# Altium schematic sheet 接收器.SchDoc
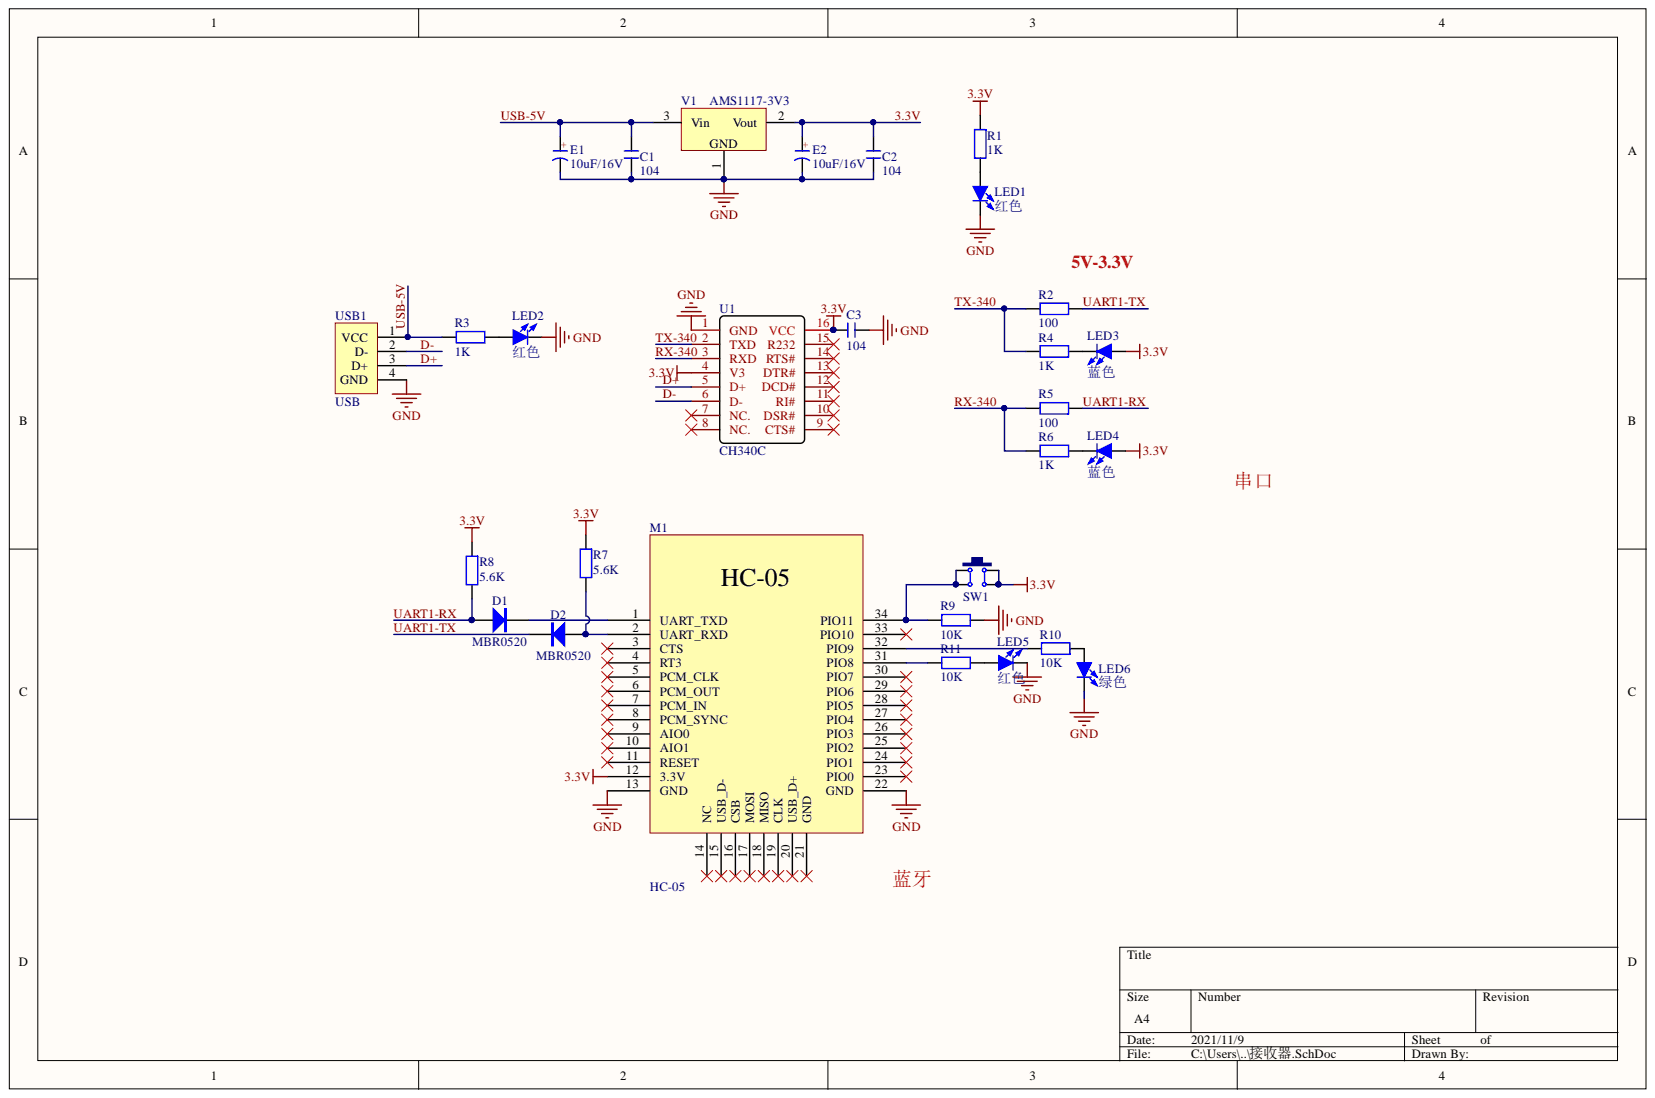
[diagram p0: 接收器.SchDoc]

## Parts
C1  104  [C 0805_L]
C2  104  [C 0805_L]
C3  104  [C 0805_L]
D1  MBR0520  [SOD-123]
D2  MBR0520  [SOD-123]
E1  10uF/16V  [CD A(3216)]
E2  10uF/16V  [CD A(3216)]
LED1  红色  [LED 0805R]
LED2  红色  [LED 0805R]
LED3  蓝色  [LED 0805B]
LED4  蓝色  [LED 0805B]
LED5  红色  [LED 0805R]
LED6  绿色  [LED 0805G]
M1  HC-05  [HC-05]
R1  1K  [R 0805_L]
R2  100  [R 0805_L]
R3  1K  [R 0805_L]
R4  1K  [R 0805_L]
R5  100  [R 0805_L]
R6  1K  [R 0805_L]
R7  5.6K  [R 0805_L]
R8  5.6K  [R 0805_L]
R9  10K  [R 0805_L]
R10  10K  [R 0805_L]
R11  10K  [R 0805_L]
SW1  4x4x1.5  [TS4x4x1.5]  (value not drawn)
U1  CH340C  [SOP16]
USB1  USB  [USB_A/P_A]
V1  AMS1117-3V3  [SOT223_L]

## Nets
GND: C1.1 C2.1 C3.2 E1.2 E2.2 LED1.2 LED2.2 LED5.2 LED6.2 M1.13(GND) M1.22(GND) R9.2 U1.1(GND) USB1.4(GND) V1.1
3.3V: C2.2 C3.1 E2.1 LED3.1 LED4.1 M1.12(3.3V) R1.2 R7.2 R8.2 SW1.2 SW1.3 U1.4(V3) U1.16(VCC) V1.2
D+: U1.5(D+) USB1.3(D+)
D-: U1.6(D-) USB1.2(D-)
RX-340: R5.1 R6.1 U1.3(RXD)
TX-340: R2.1 R4.1 U1.2(TXD)
UART1-RX: D1.1 R5.2 R8.1
UART1-TX: D2.2 R2.2
USB-5V: C1.2 E1.1 R3.2 USB1.1(VCC) V1.3
NetD1_2: D1.2 M1.1(UART_TXD)
NetD2_1: D2.1 M1.2(UART_RXD) R7.1
NetLED1_1: LED1.1 R1.1
NetLED2_1: LED2.1 R3.1
NetLED3_2: LED3.2 R4.2
NetLED4_2: LED4.2 R6.2
NetLED5_1: LED5.1 R11.2
NetLED6_1: LED6.1 R10.2
NetM1_31: M1.31(PIO8) R11.1
NetM1_32: M1.32(PIO9) R10.1
NetM1_34: M1.34(PIO11) R9.1 SW1.1 SW1.4

Not connected: M1 3(CTS) 4(RT3) 5(PCM_CLK) 6(PCM_OUT) 7(PCM_IN) 8(PCM_SYNC) 9(AIO0) 10(AIO1) 11(RESET) 14(NC) 15(USB_D-) 16(CSB) 17(MOSI) 18(MISO) 19(CLK) 20(USB_D+) 21(GND) 23(PIO0) 24(PIO1) 25(PIO2) 26(PIO3) 27(PIO4) 28(PIO5) 29(PIO6) 30(PIO7) 33(PIO10); U1 7(NC.) 8(NC.) 9(CTS#) 10(DSR#) 11(RI#) 12(DCD#) 13(DTR#) 14(RTS#) 15(R232)

## Library data of the parts
C1-C3 = C: 贴片电容; BOM名称: 无极性贴片电容; 淘宝ID: 封装库来自淘宝 ID：黄晶宝石; Component Kind: Standard; Library Name: 0.1 - 电阻-电容-电感.SchLib; 唯一出售店铺网址: https://shop463601444.taobao.com; 联系售后，技术支持: https://shop463601444.taobao.com
D1 D2 = 1N4007: 整流二极管; 封装库来自淘宝卖家 ID：黄晶宝石: 其他店铺购入均为盗版，不享受售后技术支持服务，请立即申请退款; 淘宝ID: 封装库来自淘宝 ID：黄晶宝石; BOM名称: 整流二极管; Component Kind: Standard; Library Name: 0.2 -  二极管-整流桥.SchLib; 联系售后，技术支持: https://shop463601444.taobao.com
E1 E2 = CT: 贴片钽电容; 淘宝ID: 封装库来自淘宝 ID：黄晶宝石; BOM名称: 贴片钽电容; Component Kind: Standard; Library Name: 0.1 - 电阻-电容-电感.SchLib; 联系售后: https://shop463601444.taobao.com
LED1-LED6 = LED-SMD: 贴片LED; 版权归属: 封装库来自淘宝 ID：黄晶宝石; BOM名称: 贴片LED; Component Kind: Standard; Library Name: 0.3 - LED发光二极管.SchLib; 学习资源: https://shop463601444.taobao.com
M1 = HC-05: 串口蓝牙模块; 版权归属: 源创客; BOM名称: 串口蓝牙模块; Component Kind: Standard; Library Name: 模块.SchLib; 学习资源: www.ooocke.com
R1-R11 = R: 贴片电阻; 封装库来自淘宝卖家 ID：黄晶宝石: 其他店铺购入均为盗版，不享受售后技术支持服务，请立即申请退款; 版权归属: 来自淘宝 ID：黄晶宝石; BOM名称: 贴片电阻; Component Kind: Standard; Library Name: 0.1 - 电阻-电容-电感.SchLib; 联系售后: https://shop463601444.taobao.com
SW1 = TS-030: 防水轻触开关; 版权归属: 源创客; BOM名称: 防水轻触开关; Component Kind: Standard; Library Name: 按键-开关.SchLib; 学习资源: www.ooocke.com
U1 = CH340C: 串口芯片，无需外部晶振; Component Kind: Standard; Library Name: 1.9 - CH340系列编程器芯片.SchLib
USB1 = USB-A/P: USB-A型公接口; 版权归属: 源创客; BOM名称: USB-A型公接口; Component Kind: Standard; Library Name: 接口类.SchLib; 学习资源: www.ooocke.com
V1 = AMS1117: 三端稳压芯片; BOM名称: 稳压芯片; 淘宝ID: 封装库来自淘宝 ID：黄晶宝石; Component Kind: Standard; Library Name: 1.1 - 电源类芯片.SchLib; 欢迎光临淘宝店铺: https://shop463601444.taobao.com
